# Altium schematic sheet DRAM.SchDoc(DRAM)
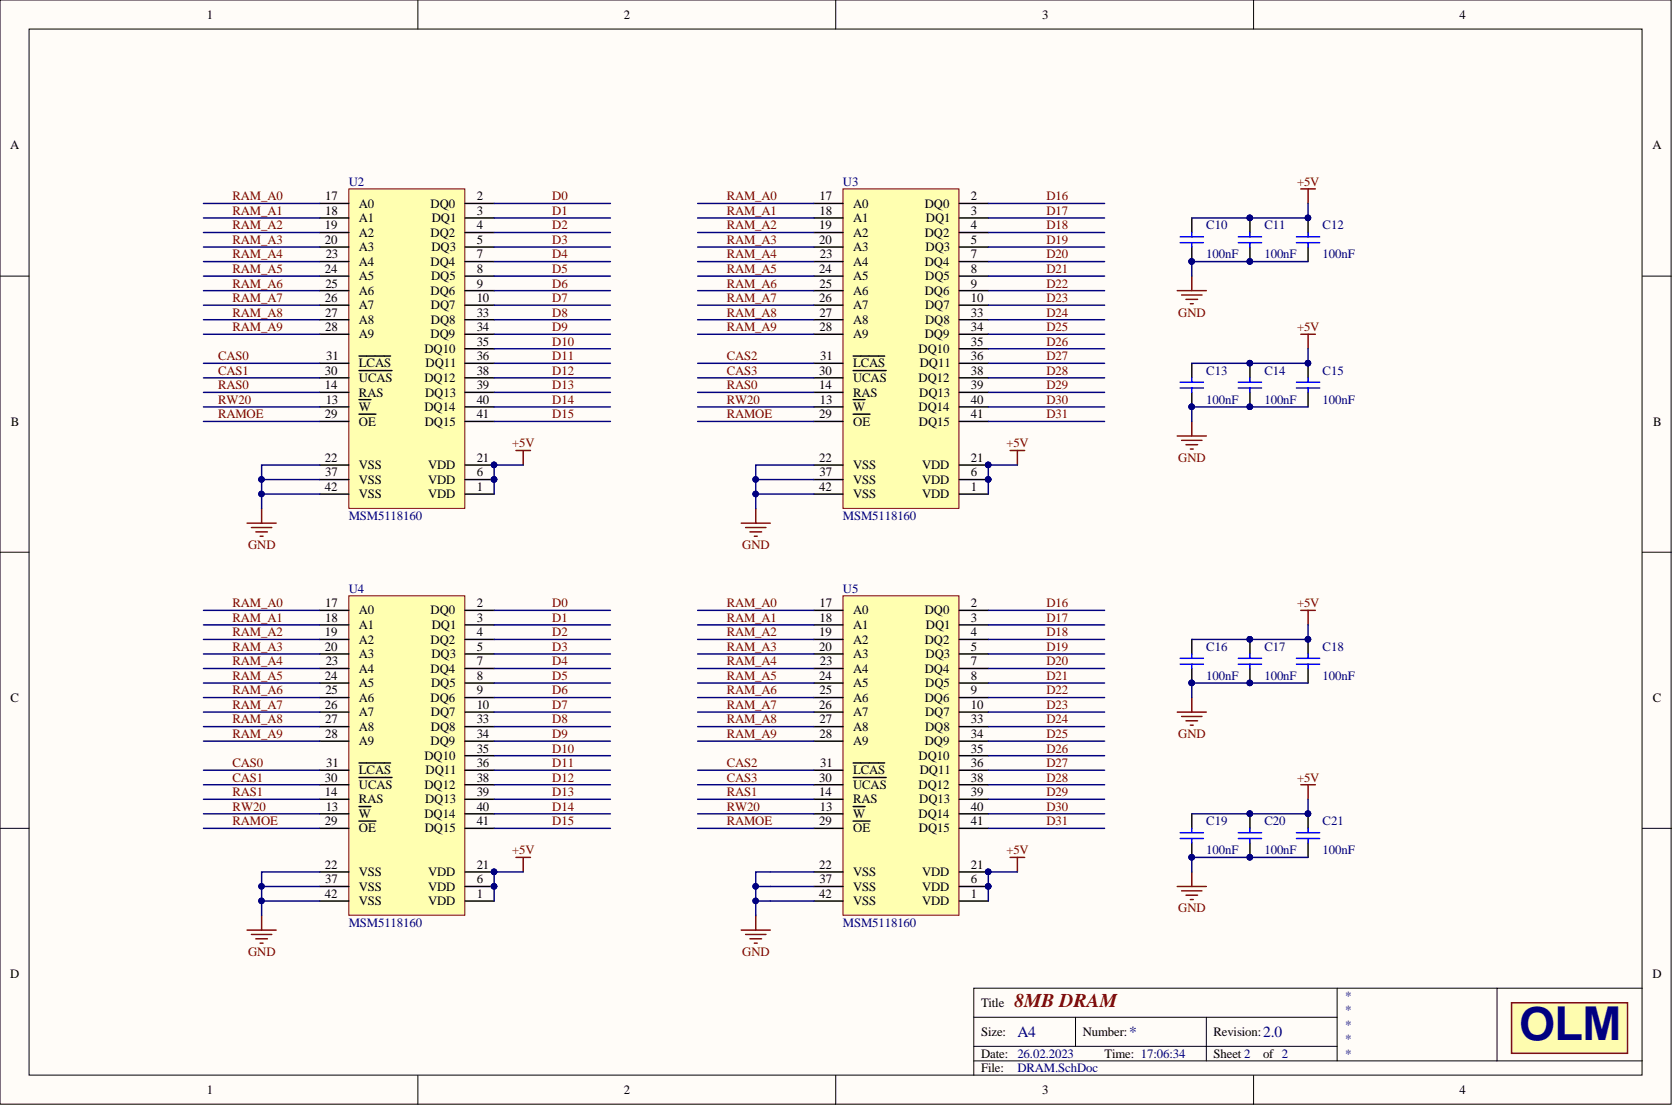
[diagram p0: DRAM.SchDoc]

## Parts
C10  value 100nF  [SM/C_0603]  (hidden comment: Cap)
C11  value 100nF  [SM/C_0603]  (hidden comment: Cap)
C12  value 100nF  [SM/C_0603]  (hidden comment: Cap)
C13  value 100nF  [SM/C_0603]  (hidden comment: Cap)
C14  value 100nF  [SM/C_0603]  (hidden comment: Cap)
C15  value 100nF  [SM/C_0603]  (hidden comment: Cap)
C16  value 100nF  [SM/C_0603]  (hidden comment: Cap)
C17  value 100nF  [SM/C_0603]  (hidden comment: Cap)
C18  value 100nF  [SM/C_0603]  (hidden comment: Cap)
C19  value 100nF  [SM/C_0603]  (hidden comment: Cap)
C20  value 100nF  [SM/C_0603]  (hidden comment: Cap)
C21  value 100nF  [SM/C_0603]  (hidden comment: Cap)
U2  value MSM5118160  [SOJ-42/400mil]  (hidden comment: 1Mx16 DRAM SOJ42)
U3  value MSM5118160  [SOJ-42/400mil]  (hidden comment: 1Mx16 DRAM SOJ42)
U4  value MSM5118160  [SOJ-42/400mil]  (hidden comment: 1Mx16 DRAM SOJ42)
U5  value MSM5118160  [SOJ-42/400mil]  (hidden comment: 1Mx16 DRAM SOJ42)

## Nets
+5V: C10.2 C11.2 C12.2 C13.2 C14.2 C15.2 C16.2 C17.2 C18.2 C19.2 C20.2 C21.2 U2.1(VDD) U2.6(VDD) U2.21(VDD) U3.1(VDD) U3.6(VDD) U3.21(VDD) U4.1(VDD) U4.6(VDD) U4.21(VDD) U5.1(VDD) U5.6(VDD) U5.21(VDD)
GND: C10.1 C11.1 C12.1 C13.1 C14.1 C15.1 C16.1 C17.1 C18.1 C19.1 C20.1 C21.1 U2.22(VSS) U2.37(VSS) U2.42(VSS) U3.22(VSS) U3.37(VSS) U3.42(VSS) U4.22(VSS) U4.37(VSS) U4.42(VSS) U5.22(VSS) U5.37(VSS) U5.42(VSS)
CAS0: U2.31(LCAS) U4.31(LCAS)
CAS1: U2.30(UCAS) U4.30(UCAS)
CAS2: U3.31(LCAS) U5.31(LCAS)
CAS3: U3.30(UCAS) U5.30(UCAS)
D0: U2.2(DQ0) U4.2(DQ0)
D1: U2.3(DQ1) U4.3(DQ1)
D2: U2.4(DQ2) U4.4(DQ2)
D3: U2.5(DQ3) U4.5(DQ3)
D4: U2.7(DQ4) U4.7(DQ4)
D5: U2.8(DQ5) U4.8(DQ5)
D6: U2.9(DQ6) U4.9(DQ6)
D7: U2.10(DQ7) U4.10(DQ7)
D8: U2.33(DQ8) U4.33(DQ8)
D9: U2.34(DQ9) U4.34(DQ9)
D10: U2.35(DQ10) U4.35(DQ10)
D11: U2.36(DQ11) U4.36(DQ11)
D12: U2.38(DQ12) U4.38(DQ12)
D13: U2.39(DQ13) U4.39(DQ13)
D14: U2.40(DQ14) U4.40(DQ14)
D15: U2.41(DQ15) U4.41(DQ15)
D16: U3.2(DQ0) U5.2(DQ0)
D17: U3.3(DQ1) U5.3(DQ1)
D18: U3.4(DQ2) U5.4(DQ2)
D19: U3.5(DQ3) U5.5(DQ3)
D20: U3.7(DQ4) U5.7(DQ4)
D21: U3.8(DQ5) U5.8(DQ5)
D22: U3.9(DQ6) U5.9(DQ6)
D23: U3.10(DQ7) U5.10(DQ7)
D24: U3.33(DQ8) U5.33(DQ8)
D25: U3.34(DQ9) U5.34(DQ9)
D26: U3.35(DQ10) U5.35(DQ10)
D27: U3.36(DQ11) U5.36(DQ11)
D28: U3.38(DQ12) U5.38(DQ12)
D29: U3.39(DQ13) U5.39(DQ13)
D30: U3.40(DQ14) U5.40(DQ14)
D31: U3.41(DQ15) U5.41(DQ15)
RAM_A0: U2.17(A0) U3.17(A0) U4.17(A0) U5.17(A0)
RAM_A1: U2.18(A1) U3.18(A1) U4.18(A1) U5.18(A1)
RAM_A2: U2.19(A2) U3.19(A2) U4.19(A2) U5.19(A2)
RAM_A3: U2.20(A3) U3.20(A3) U4.20(A3) U5.20(A3)
RAM_A4: U2.23(A4) U3.23(A4) U4.23(A4) U5.23(A4)
RAM_A5: U2.24(A5) U3.24(A5) U4.24(A5) U5.24(A5)
RAM_A6: U2.25(A6) U3.25(A6) U4.25(A6) U5.25(A6)
RAM_A7: U2.26(A7) U3.26(A7) U4.26(A7) U5.26(A7)
RAM_A8: U2.27(A8) U3.27(A8) U4.27(A8) U5.27(A8)
RAM_A9: U2.28(A9) U3.28(A9) U4.28(A9) U5.28(A9)
RAMOE: U2.29(OE) U3.29(OE) U4.29(OE) U5.29(OE)
RAS0: U2.14(RAS) U3.14(RAS)
RAS1: U4.14(RAS) U5.14(RAS)
RW20: U2.13(W) U3.13(W) U4.13(W) U5.13(W)

## Library data of the parts
C10-C21 = Cap ceramic: CAP-100Nf; Component Kind: Standard; Library Name: New.SCHLIB
U2-U5 = 1Mx16 DRAM: Component Kind: Standard; Description: 1Mx16 DRAM; Library Name: Memory.SchLib
